# Altium schematic sheet MCU_ascii.SchDoc(MCU_ascii)
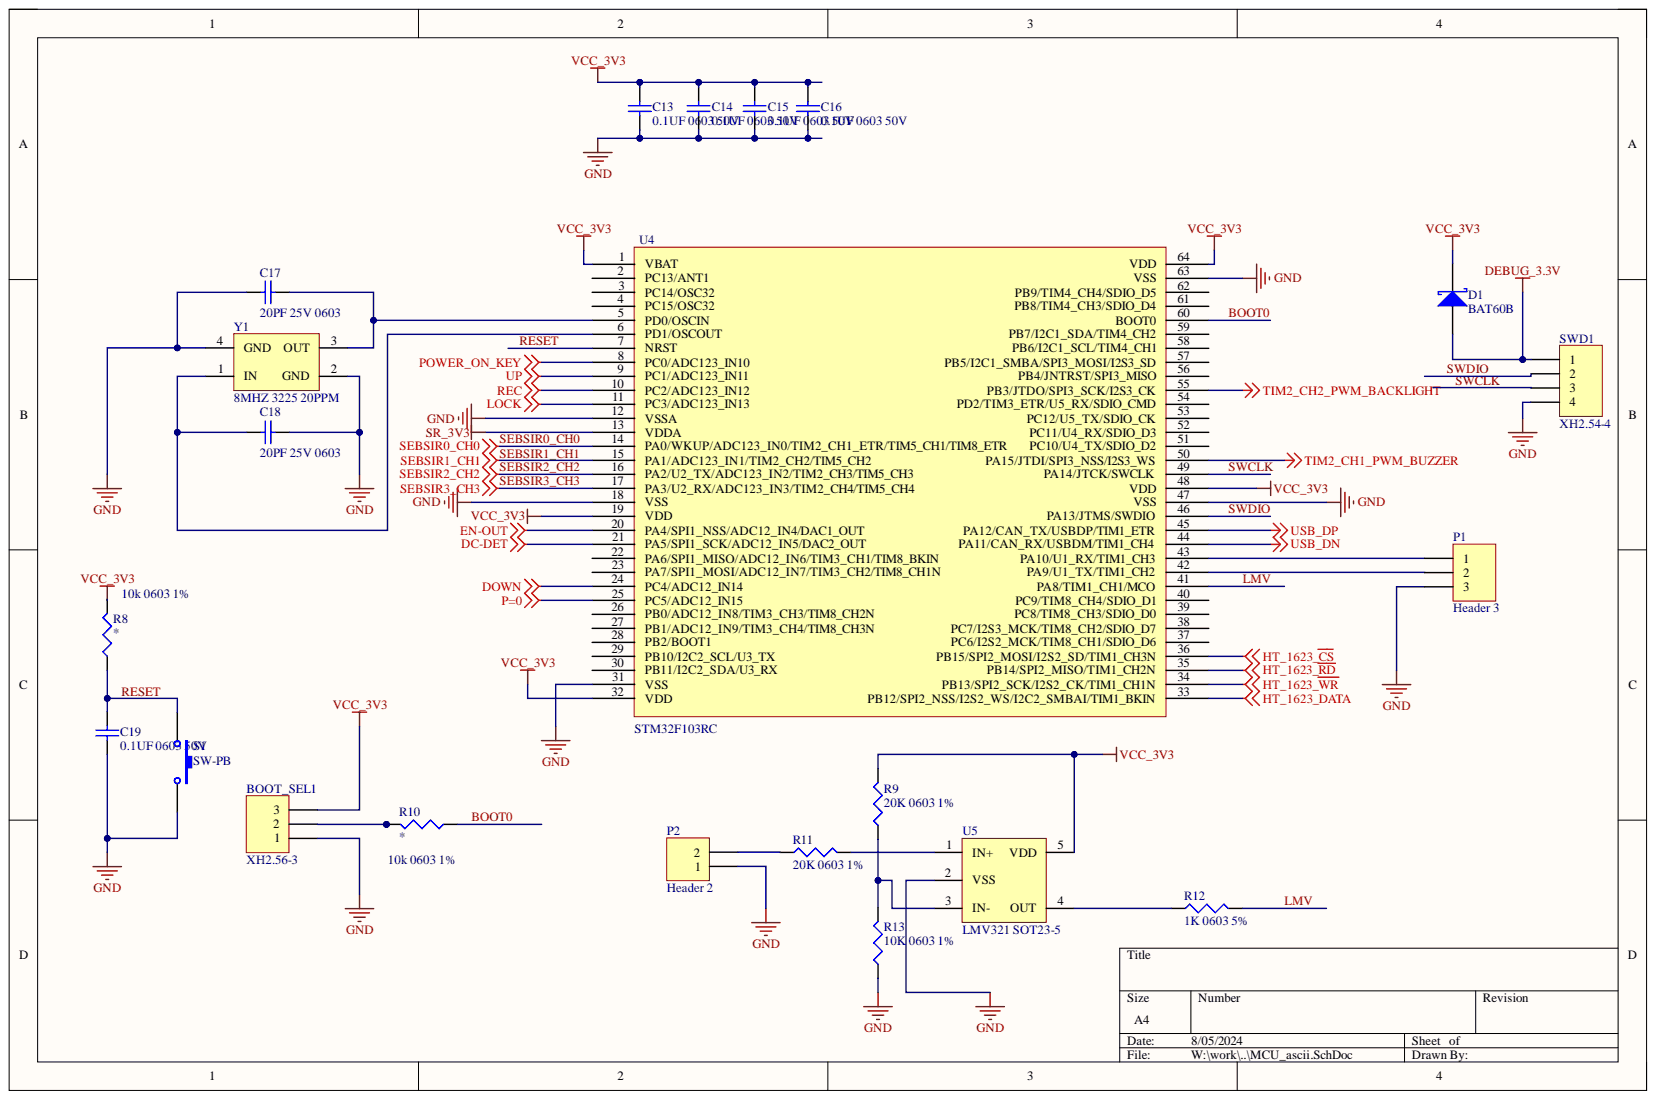
[diagram p0: MCU_ascii.SchDoc]

## Parts
BOOT_SEL1  XH2.56-3  [HDR1X3]
C13  0.1UF 0603 50V  [CAP0603_3D]
C14  0.1UF 0603 50V  封装: 0603  耐压: 50V  [CAP0603_3D]
C15  0.1UF 0603 50V  封装: 0603  耐压: 50V  [CAP0603_3D]
C16  0.1UF 0603 50V  封装: 0603  耐压: 50V  [CAP0603_3D]
C17  20PF 25V 0603  [CAP0603_3D]
C18  20PF 25V 0603  封装: 0603  [CAP0603_3D]
C19  0.1UF 0603 50V  [CAP0603_3D]
D1  BAT60B  [SOD-323]
P1  Header 3  [HDR1X3]
P2  Header 2  [HDR1X2]
R8  10k 0603 1%  [RES0603_3D]
R9  20K 0603 1%  [RES0603_3D]
R10  10k 0603 1%  [RES0603_3D]
R11  20K 0603 1%  精度误差: 1%  [RES0603_3D]
R12  1K 0603 5%  [RES0603_3D]
R13  10K 0603 1%  [RES0603_3D]
S1  SW-PB  [SPST-2]
SWD1  XH2.54-4  [HDR1X4]
U4  STM32F103RC  [LQFP64-ST]
U5  LMV321 SOT23-5  [SOT23-5]
Y1  8MHZ 3225 20PPM  [晶振3225_3D]

## Nets
DC-DET: U4.21(PA5/SPI1_SCK/ADC12_IN5/DAC2_OUT)
DEBUG_3.3V: D1.2 SWD1.1
DOWN: U4.24(PC4/ADC12_IN14)
EN-OUT: U4.20(PA4/SPI1_NSS/ADC12_IN4/DAC1_OUT)
GND: BOOT_SEL1.1 C13.1 C14.1 C15.1 C16.1 C17.1 C18.2 C19.1 P1.3 P2.1 R13.1 S1.2 SWD1.4 U4.12(VSSA) U4.18(VSS) U4.31(VSS) U4.47(VSS) U4.63(VSS) U5.2(VSS) Y1.2(GND) Y1.4(GND)
HT_1623_~{CS}: U4.36(PB15/SPI2_MOSI/I2S2_SD/TIM1_CH3N)
HT_1623_DATA: U4.33(PB12/SPI2_NSS/I2S2_WS/I2C2_SMBAI/TIM1_BKIN)
HT_1623_~{RD}: U4.35(PB14/SPI2_MISO/TIM1_CH2N)
HT_1623_~{WR}: U4.34(PB13/SPI2_SCK/I2S2_CK/TIM1_CH1N)
LOCK: U4.11(PC3/ADC123_IN13)
P=0: U4.25(PC5/ADC12_IN15)
POWER_ON_KEY: U4.8(PC0/ADC123_IN10)
REC: U4.10(PC2/ADC123_IN12)
SR_3V3: U4.13(VDDA)
TIM2_CH1_PWM_BUZZER: U4.50(PA15/JTDI/SPI3_NSS/I2S3_WS)
TIM2_CH2_PWM_BACKLIGHT: U4.55(PB3/JTDO/SPI3_SCK/I2S3_CK)
UP: U4.9(PC1/ADC123_IN11)
USB_DN: U4.44(PA11/CAN_RX/USBDM/TIM1_CH4)
USB_DP: U4.45(PA12/CAN_TX/USBDP/TIM1_ETR)
VCC_3V3: BOOT_SEL1.3 C13.2 C14.2 C15.2 C16.2 D1.1 R8.2 R9.2 U4.1(VBAT) U4.19(VDD) U4.32(VDD) U4.48(VDD) U4.64(VDD) U5.5(VDD)
BOOT0: R10.1 U4.60(BOOT0)
LMV: R12.2 U4.41(PA8/TIM1_CH1/MCO)
RESET: C19.2 R8.1 S1.1 U4.7(NRST)
SEBSIR0_CH0: U4.14(PA0/WKUP/ADC123_IN0/TIM2_CH1_ETR/TIM5_CH1/TIM8_ETR)
SEBSIR1_CH1: U4.15(PA1/ADC123_IN1/TIM2_CH2/TIM5_CH2)
SEBSIR2_CH2: U4.16(PA2/U2_TX/ADC123_IN2/TIM2_CH3/TIM5_CH3)
SEBSIR3_CH3: U4.17(PA3/U2_RX/ADC123_IN3/TIM2_CH4/TIM5_CH4)
SWCLK: SWD1.3 U4.49(PA14/JTCK/SWCLK)
SWDIO: SWD1.2 U4.46(PA13/JTMS/SWDIO)
NetBOOT_SEL1_2: BOOT_SEL1.2 R10.2
NetC17_2: C17.2 U4.5(PD0/OSCIN) Y1.3(OUT)
NetC18_1: C18.1 U4.6(PD1/OSCOUT) Y1.1(IN)
NetP1_1: P1.1 U4.43(PA10/U1_RX/TIM1_CH3)
NetP1_2: P1.2 U4.42(PA9/U1_TX/TIM1_CH2)
NetP2_2: P2.2 R11.1
NetR9_1: R9.1 R13.2 U5.3(IN-)
NetR11_2: R11.2 U5.1(IN+)
NetR12_1: R12.1 U5.4(OUT)

Not connected: U4 2(PC13/ANT1) 3(PC14/OSC32) 4(PC15/OSC32) 22(PA6/SPI1_MISO/ADC12_IN6/TIM3_CH1/TIM8_BKIN) 23(PA7/SPI1_MOSI/ADC12_IN7/TIM3_CH2/TIM8_CH1N) 26(PB0/ADC12_IN8/TIM3_CH3/TIM8_CH2N) 27(PB1/ADC12_IN9/TIM3_CH4/TIM8_CH3N) 28(PB2/BOOT1) 29(PB10/I2C2_SCL/U3_TX) 30(PB11/I2C2_SDA/U3_RX) 37(PC6/I2S2_MCK/TIM8_CH1/SDIO_D6) 38(PC7/I2S3_MCK/TIM8_CH2/SDIO_D7) 39(PC8/TIM8_CH3/SDIO_D0) 40(PC9/TIM8_CH4/SDIO_D1) 51(PC10/U4_TX/SDIO_D2) 52(PC11/U4_RX/SDIO_D3) 53(PC12/U5_TX/SDIO_CK) 54(PD2/TIM3_ETR/U5_RX/SDIO_CMD) 56(PB4/JNTRST/SPI3_MISO) 57(PB5/I2C1_SMBA/SPI3_MOSI/I2S3_SD) 58(PB6/I2C1_SCL/TIM4_CH1) 59(PB7/I2C1_SDA/TIM4_CH2) 61(PB8/TIM4_CH3/SDIO_D4) 62(PB9/TIM4_CH4/SDIO_D5)

## Library data of the parts
BOOT_SEL1 P1 = Header 3: Header, 3-Pin; Component Kind: Standard; LatestRevisionDate: 17-Jul-2002; LatestRevisionNote: Re-released for DXP Platform.; Library Name: Miscellaneous Connectors.IntLib; Signal Integrity: Connector; Publisher: Altium Limited
C13 C19 = CAP_SMT: 贴片多层陶瓷电容; 容值误差: 10%; 封装: 0603; Component Kind: Standard; 耐压: 50V; Library Name: hwt.SchLib
C14-C16 = CAP_SMT: 贴片多层陶瓷电容; 容值误差: 10%; Component Kind: Standard; Library Name: hwt.SchLib
C17 = CAP_SMT: 贴片多层陶瓷电容; 容值误差: 10%; 封装: 0603; 耐压: 50V; Component Kind: Standard; Library Name: hwt.SchLib
C18 = CAP_SMT: 贴片多层陶瓷电容; 容值误差: 10%; 耐压: 50V; Component Kind: Standard; Library Name: hwt.SchLib
D1 = BAT60B: Silicon AF Schottky Rectifier Diode; Component Kind: Standard; ComponentLink1Description: Manufacturer Link; ComponentLink1URL: http://www.infineon.com/; ComponentLink2Description: Datasheet; ComponentLink2URL: http://www.infineon.com/upload/Document/cmc_upload/documents/009/394/bat60bseries.pdf; DatasheetDocument: Jan-1999; LatestRevisionDate: 19-Mar-2004; LatestRevisionNote: Silkscreen size reduced.; Library Name: Infineon Discrete Diode.IntLib; PackageDocument: Sep-2000; PackageReference: SOD-323; Published: 21-Mar-2003; Publisher: Altium Limited
P2 = Header 2: Header, 2-Pin; Component Kind: Standard; LatestRevisionDate: 17-Jul-2002; LatestRevisionNote: Re-released for DXP Platform.; Library Name: Miscellaneous Connectors.IntLib; Signal Integrity: Connector; Publisher: Altium Limited
R8-R11 R13 = RES_SMT: Component Kind: Standard; Library Name: hwt.SchLib
  R8-R10 R13: 精度误差: 1%
R12 = RES_SMT: 精度误差: 1%; Component Kind: Standard; Library Name: hwt.SchLib
S1 = SW-PB: Switch; Component Kind: Standard; LatestRevisionDate: 17-Jul-2002; LatestRevisionNote: Re-released for DXP Platform.; Library Name: Miscellaneous Devices.IntLib; PackageDescription: Switch; 2 Leads; PackageReference: SPST-2; Published: 24-Mar-1999; Publisher: Altium Limited
SWD1 = Header 4: Header, 4-Pin; Component Kind: Standard; LatestRevisionDate: 17-Jul-2002; LatestRevisionNote: Re-released for DXP Platform.; Library Name: Miscellaneous Connectors.IntLib; Signal Integrity: Connector; Publisher: Altium Limited
Y1 = sx3225: Component Kind: Standard; Library Name: hwt.SchLib
U4 = STM32F103RC: Component Kind: Standard; Library Name: hwt.SchLib
U5 = LMV321 SOT23-5: Component Kind: Standard; Library Name: hwt.SchLib
